# Altium schematic sheet Main.SchDoc(Main)
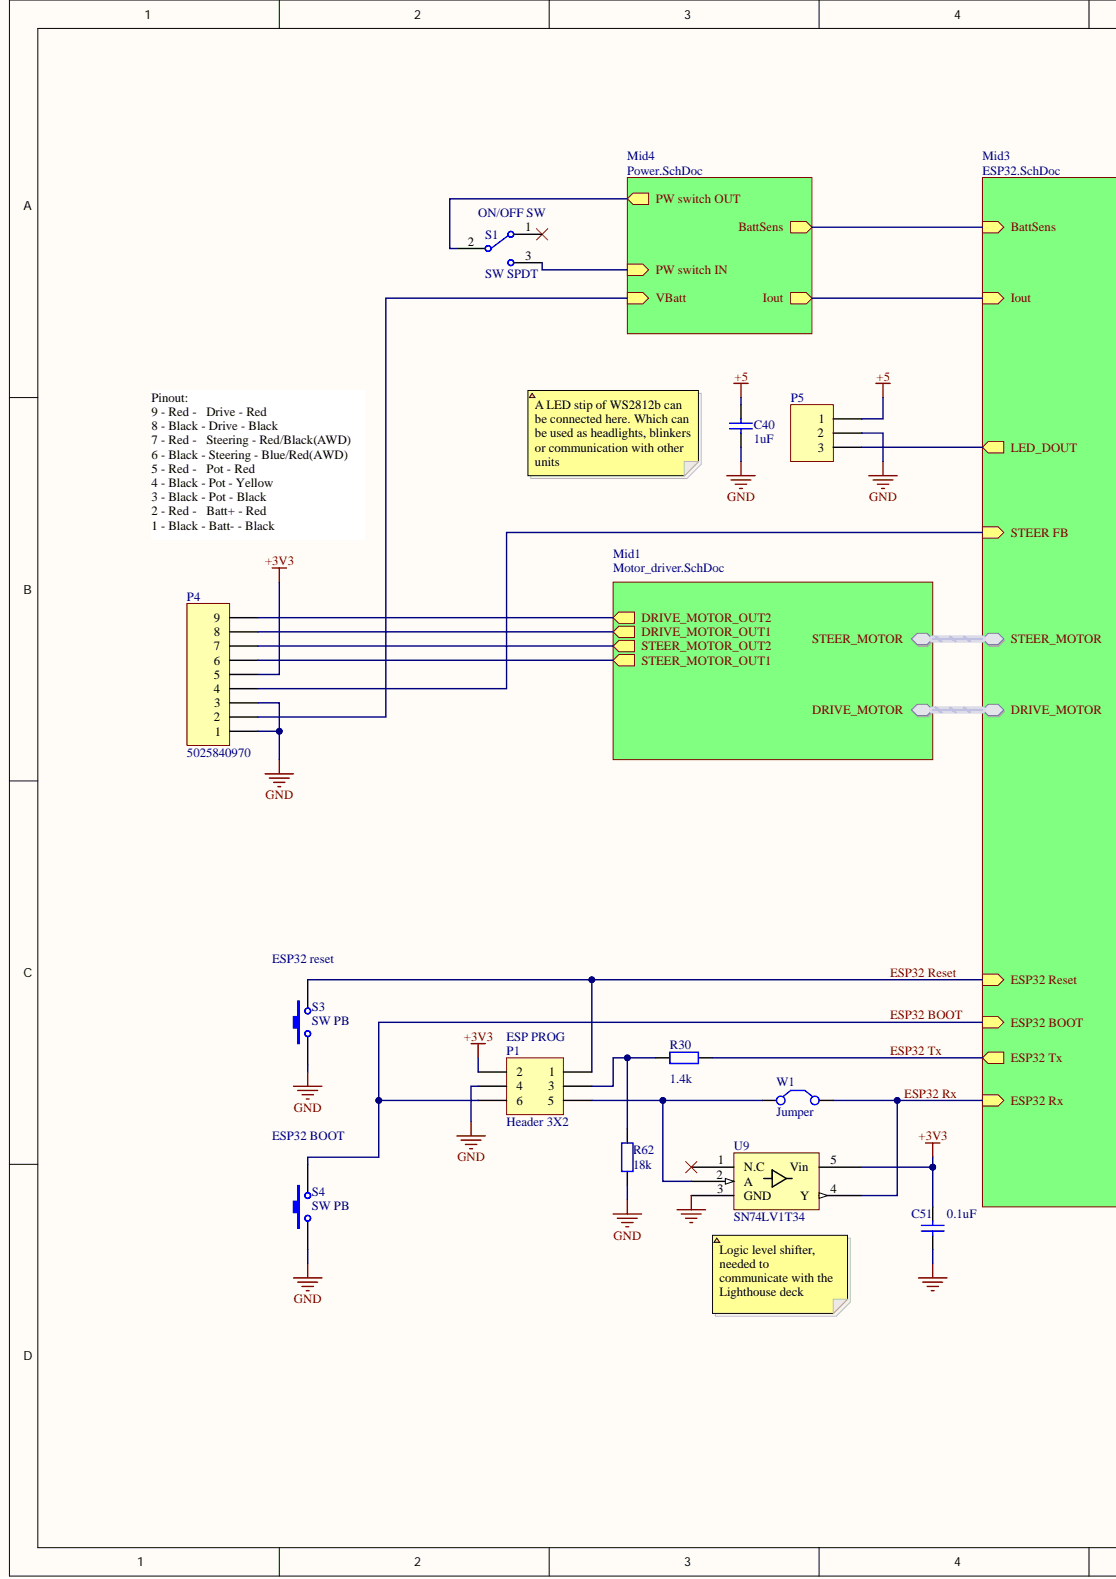
[diagram p0: Main.SchDoc, left]
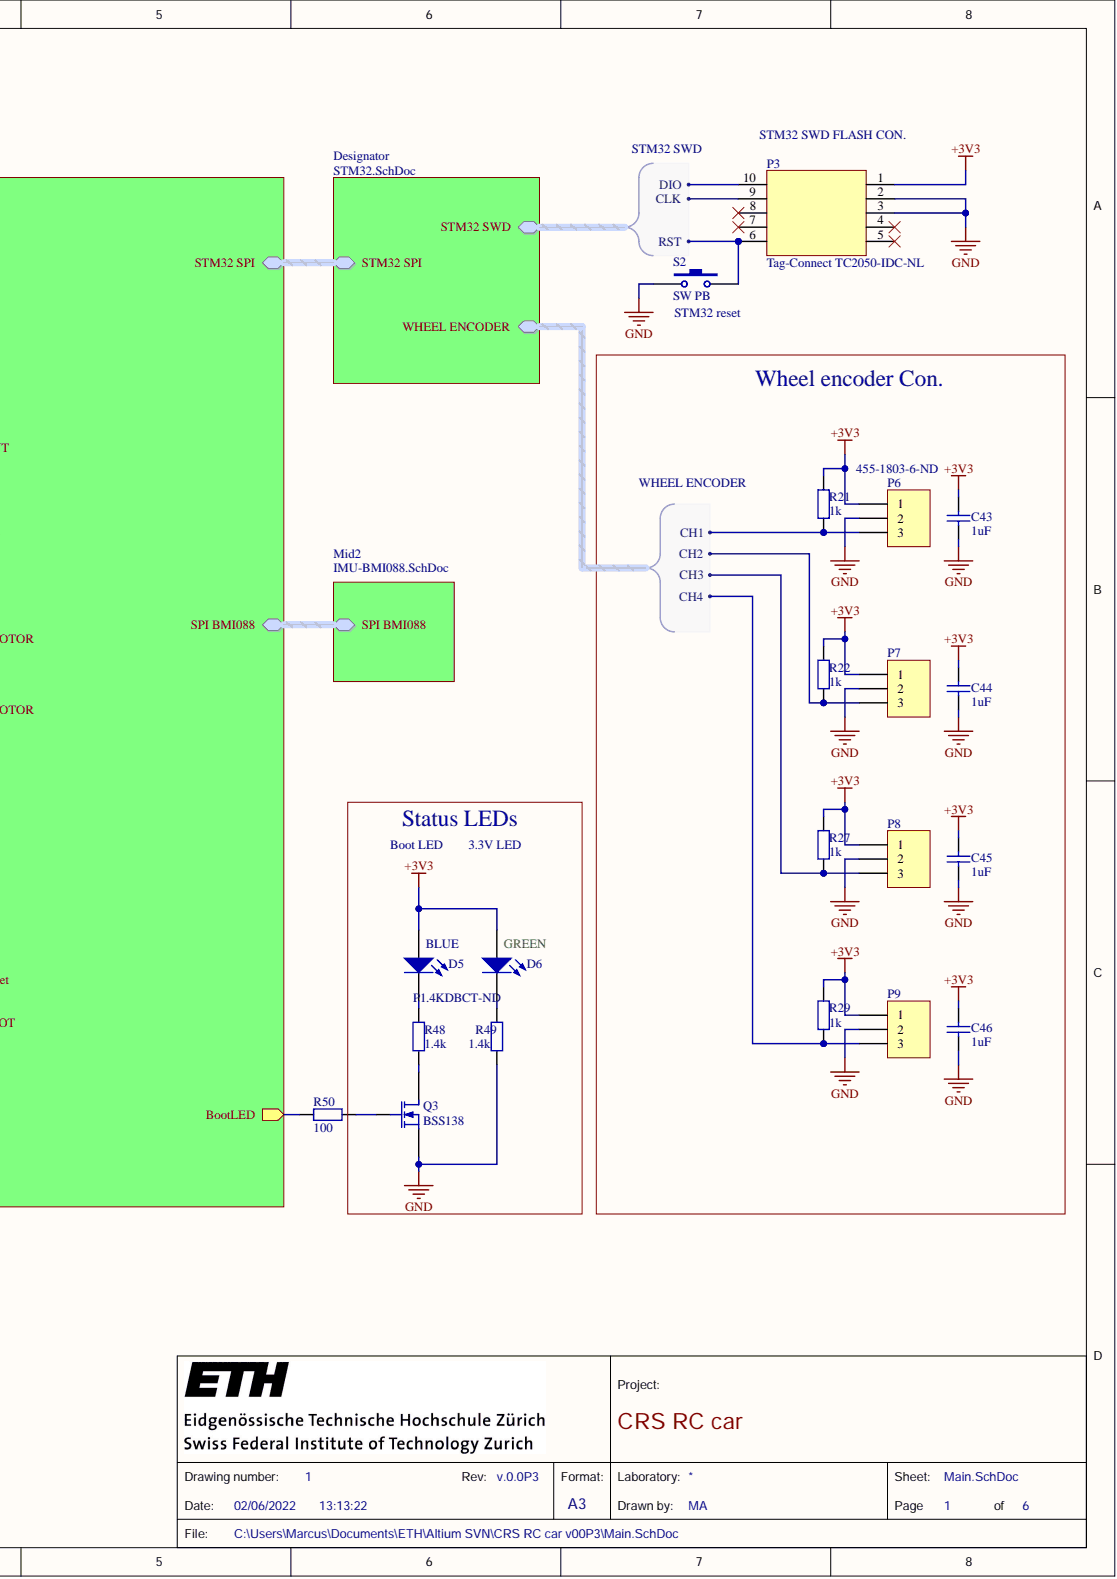
[diagram p1: Main.SchDoc, right]

## Parts
C40  1uF  [CAP_0603i_1608m -N]
C43  1uF  [CAP_0603i_1608m -N]
C44  1uF  [CAP_0603i_1608m -N]
C45  1uF  [CAP_0603i_1608m -N]
C46  1uF  [CAP_0603i_1608m -N]
C51  0.1uF  [CAP_0603i_1608m -N]
D5  (no value)  [LED_0603i_1608m-n]
D6  (no value)  [LED_0603i_1608m-n]
P1  Header 3X2  [1.27mm HDR 2X3 THT - KEY]
P3  Tag-Connect TC2050-IDC-NL  [TAG-CONNECT TC2050-IDC-NL 10-Pin]
P4  5025840970  [CLIK-Mate 1.5mm 9 pin 50258409xx]
P5  JST_SH/SR 3POS 1MM - Side entry  [JST_SH/SR 3POS 1MM - Side entry]  (value not drawn)
P6  Supplier Part Number 1: 455-1803-6-ND  [JST_SH/SR 3POS 1MM - Side entry]  (hidden comment: JST_SH/SR 3POS 1MM - Side entry)
P7  JST_SH/SR 3POS 1MM - Side entry  [JST_SH/SR 3POS 1MM - Side entry]  (value not drawn)
P8  JST_SH/SR 3POS 1MM - Side entry  [JST_SH/SR 3POS 1MM - Side entry]  (value not drawn)
P9  JST_SH/SR 3POS 1MM - Side entry  [JST_SH/SR 3POS 1MM - Side entry]  (value not drawn)
Q3  BSS138  [SOT23-N]
R21  1k  [RES_0603i_1608m -N]
R22  1k  [RES_0603i_1608m -N]
R27  1k  [RES_0603i_1608m -N]
R29  1k  [RES_0603i_1608m -N]
R30  1.4k  [RES_0603i_1608m -N]
R48  1.4k  Supplier Part Number 1: P1.4KDBCT-ND  [RES_0603i_1608m -N]
R49  1.4k  [RES_0603i_1608m -N]
R50  100  [RES_0603i_1608m -N]
R62  18k  [RES_0603i_1608m -N]
S1  SW SPDT  [WS-SLS]
S2  SW PB  [WS-TASV]
S3  SW PB  [WS-TASV]
S4  SW PB  [WS-TASV]
U9  SN74LV1T34  [SOT23-5-N]
W1  Jumper  [RES_0603i_1608m -N]

## Nets
+3V3: C43.2 C44.2 C45.2 C46.2 C51.1 D5.1 D6.1 P1.2 P3.1 P4.5 P6.1 P7.1 P8.1 P9.1 R21.2 R22.2 R27.2 R29.2 U9.5(Vin)
+5: C40.2 P5.1
GND: C40.1 C43.1 C44.1 C45.1 C46.1 C51.2 P1.4 P3.2 P3.3 P4.1 P4.3 P5.2 P6.2 P7.2 P8.2 P9.2 Q3.2 R49.1 R62.1 S2.1 S3.1 S4.1 U9.3(GND)
ESP32 BOOT: P1.6 S4.2
ESP32 Reset: P1.1 S3.2
ESP32 Rx: U9.4(Y) W1.2
ESP32 Tx: R30.1
NetD5_2: D5.2 R48.2
NetD6_2: D6.2 R49.2
NetP1_3: P1.3 R30.2 R62.2
NetP1_5: P1.5 U9.2(A) W1.1
NetP3_4: P3.4
NetP3_5: P3.5
NetP3_6: P3.6 S2.2
NetP3_7: P3.7
NetP3_8: P3.8
NetP3_9: P3.9
NetP3_10: P3.10
NetP4_2: P4.2
NetP4_4: P4.4
NetP4_6: P4.6
NetP4_7: P4.7
NetP4_8: P4.8
NetP4_9: P4.9
NetP5_3: P5.3
NetP6_3: P6.3 R21.1
NetP7_3: P7.3 R22.1
NetP8_3: P8.3 R27.1
NetP9_3: P9.3 R29.1
NetQ3_1: Q3.1 R50.1
NetQ3_3: Q3.3 R48.1
NetR50_2: R50.2
NetS1_1: S1.1
NetS1_2: S1.2
NetS1_3: S1.3
NetU9_1: U9.1(N.C)
BattSens:
DRIVE_MOTOR.DRIVE_MOTOR_FAULT:
DRIVE_MOTOR.DRIVE_MOTOR_PHASE:
DRIVE_MOTOR.DRIVE_MOTOR_PWM:
Iout:
SPI BMI088.CSB_ACCEL:
SPI BMI088.CSB_GYRO:
SPI BMI088.SPI MISO:
SPI BMI088.SPI MOSI:
SPI BMI088.SPI SCK:
STEER_MOTOR.STEER_MOTOR_FAULT:
STEER_MOTOR.STEER_MOTOR_PHASE:
STEER_MOTOR.STEER_MOTOR_PWM:
STM32 SPI.CS:
STM32 SPI.MISO:
STM32 SPI.MOSI:
STM32 SPI.SCK:

## Library data of the parts
C40 C43-C46 C51 = Cap: capacitor; Component Kind: Standard; Signal Integrity: Cap; Simulation: CAPACITOR; Supplier 1: Digi-Key; Library Name: IDSC std generic parts.IntLib
  C40 C43-C46: Supplier Part Number 1: 445-1604-1-ND
  C51: Supplier Part Number 1: 1276-1006-1-ND
D5 D6 = Diode LED: LED; Component Kind: Standard; Simulation: LED0; Library Name: IDSC std generic parts.IntLib
P1 = Header 3X2: Header, 3-Pin, Dual row; Component Kind: Standard; Signal Integrity: Connector; Supplier 1: Mouser; Supplier Part Number 1: 649-221511-00006T4LF; Library Name: IDSC std connectors.IntLib
P3 = Tag-Connect TC2050-IDC-NL-No pin assigned: 10-pin pogo pin programing port; Component Kind: Standard; Library Name: IDSC std connectors.IntLib
P4 = Molex CLIK-Mate 50258409xx: 1.50mm Pitch, CLIK-Mate PCB Receptacle, Single Row, Surface Mount, Tin Plating, Positive Lock, 9 Circuits; Manufacturer: Molex; Component Kind: Standard; Signal Integrity: Connector; Supplier 1: Digi-Key; Supplier Part Number 1: WM24261CT-ND; Library Name: IDSC std connectors.IntLib
P5-P9 = JST_SH/SR 3POS 1MM - Side entry: JST SM04B-SRSS-TB same footprint between SR/SZ and SH series; Manufacturer Part Number: SM03B-SRSS-TB(LF)(SN); Component Kind: Standard; Manufacturer: JST; Signal Integrity: Connector; Library Name: IDSC std connectors.IntLib
  P6: Supplier 1: Digi-Key
Q3 = MOSFET-N: N-Channel MOSFET; Manufacturer: ON Semi.; Component Kind: Standard; Manufacturer Part Number: BSS138; Simulation: NMOS; Supplier: Digi-Key; Supplier 1: Digi-Key; Supplier Part Number: BSS138CT-ND; Supplier Part Number 1: BSS138NH6327XTSA2CT-ND; Library Name: IDSC std generic parts.IntLib
R21 R22 R27 R29 R30 R48-R50 R62 = Res: Resistor; Component Kind: Standard; Signal Integrity: Res; Simulation: RESISTOR; Library Name: IDSC std generic parts.IntLib
  R21 R22 R27 R29: Supplier 1: Digi-Key; Supplier Part Number 1: 311-1.00KHRCT-ND
  R48: Supplier 1: Digi-Key
  R62: Supplier 1: Digi-Key; Supplier Part Number 1: P18.0KHCT-ND
S1 = SW SPDT: Single-Pole, Double-Throw Switch; Component Kind: Standard; Supplier 1: Digi-Key; Supplier Part Number 1: 732-13665-1-ND; Library Name: IDSC std generic parts.IntLib
S2-S4 = SW PB: Switch; Component Kind: Standard; Supplier 1: Digi-Key; Supplier Part Number 1: 732-7039-1-ND; Library Name: IDSC std generic parts.IntLib
U9 = SN74LV1T34: Single Power Supply Single Buffer GATE CMOS Logic Level Shifter; Manufacturer: Texas Instruments; Manufacturer Part Number: SN74LV1T34DBVR; Value: IC BUF NON-INVERT 5.5V SC70-5; Component Kind: Standard; Supplier: Digi-Key; Supplier 1: TI store; Supplier Part Number: 296-37176-1-ND; Supplier Part Number 1: SN74LV1T34DBVR; Library Name: IDSC_component_lib.SchLib
W1 = Jumper: Jumper Wire; Component Kind: Standard; Library Name: IDSC std generic parts.IntLib
